# Altium schematic sheet MPU.SchDoc
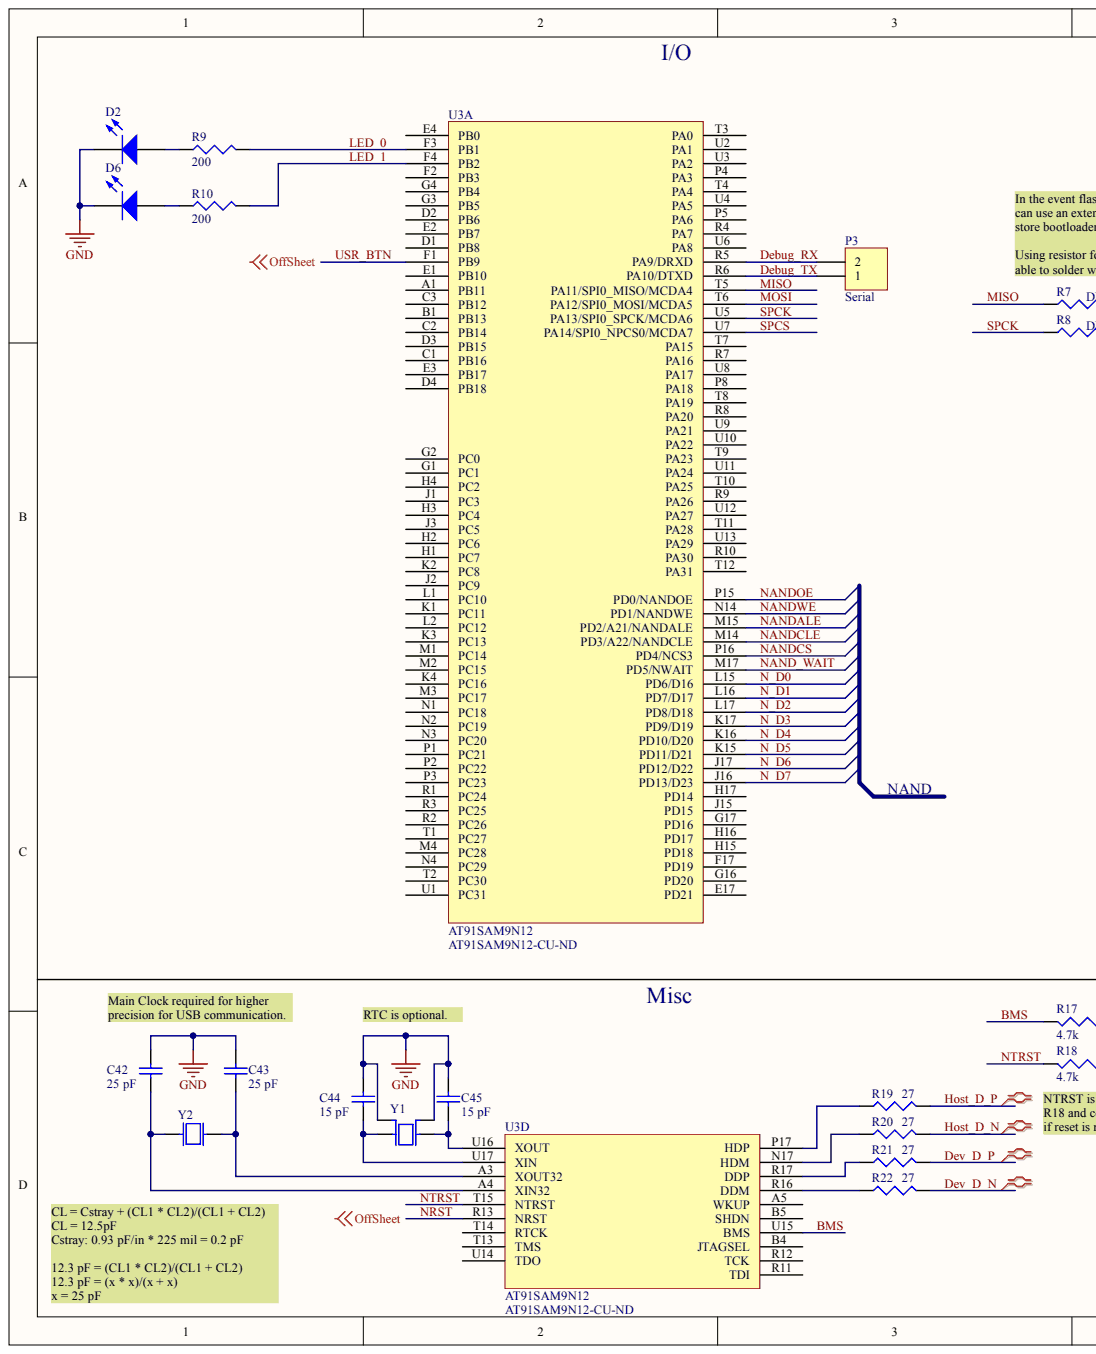
[diagram p0: MPU.SchDoc, left]
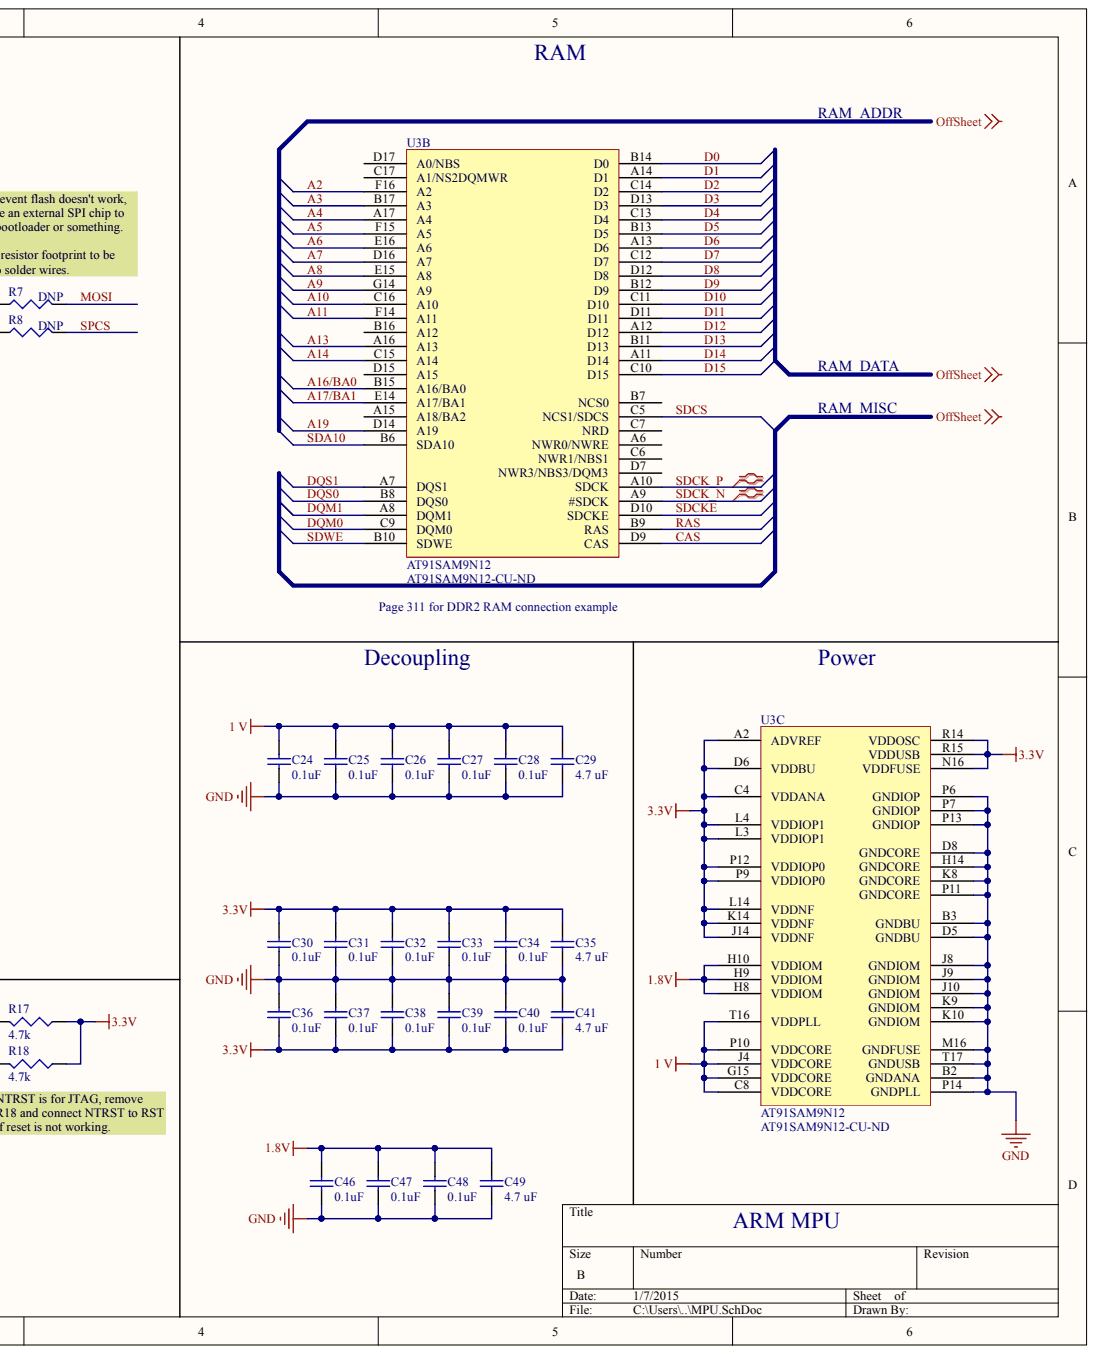
[diagram p1: MPU.SchDoc, right]

## Parts
C24  value 0.1uF  [0402]  (hidden comment: CL05B104KQ5NNNC)
C25  value 0.1uF  [0402]  (hidden comment: CL05B104KQ5NNNC)
C26  value 0.1uF  [0402]  (hidden comment: CL05B104KQ5NNNC)
C27  value 0.1uF  [0402]  (hidden comment: CL05B104KQ5NNNC)
C28  value 0.1uF  [0402]  (hidden comment: CL05B104KQ5NNNC)
C29  value 4.7 uF  [1608[0603]]  (hidden comment: CL10B475KQ8NQNC)
C30  value 0.1uF  [0402]  (hidden comment: CL05B104KQ5NNNC)
C31  value 0.1uF  [0402]  (hidden comment: CL05B104KQ5NNNC)
C32  value 0.1uF  [0402]  (hidden comment: CL05B104KQ5NNNC)
C33  value 0.1uF  [0402]  (hidden comment: CL05B104KQ5NNNC)
C34  value 0.1uF  [0402]  (hidden comment: CL05B104KQ5NNNC)
C35  value 4.7 uF  [1608[0603]]  (hidden comment: CL10B475KQ8NQNC)
C36  value 0.1uF  [0402]  (hidden comment: CL05B104KQ5NNNC)
C37  value 0.1uF  [0402]  (hidden comment: CL05B104KQ5NNNC)
C38  value 0.1uF  [0402]  (hidden comment: CL05B104KQ5NNNC)
C39  value 0.1uF  [0402]  (hidden comment: CL05B104KQ5NNNC)
C40  value 0.1uF  [0402]  (hidden comment: CL05B104KQ5NNNC)
C41  value 4.7 uF  [1608[0603]]  (hidden comment: CL10B475KQ8NQNC)
C42  value 25 pF  [0402]  (hidden comment: CL05C220JB5NNNC)
C43  value 25 pF  [0402]  (hidden comment: CL05C220JB5NNNC)
C44  value 15 pF  [0402]  (hidden comment: CL05C150JB5NNNC)
C45  value 15 pF  [0402]  (hidden comment: CL05C150JB5NNNC)
C46  value 0.1uF  [0402]  (hidden comment: CL05B104KQ5NNNC)
C47  value 0.1uF  [0402]  (hidden comment: CL05B104KQ5NNNC)
C48  value 0.1uF  [0402]  (hidden comment: CL05B104KQ5NNNC)
C49  value 4.7 uF  [1608[0603]]  (hidden comment: CL10B475KQ8NQNC)
D2  LSQ976-Z  [LSQ976-Z]  (value not drawn)
D6  LSQ976-Z  [LSQ976-Z]  (value not drawn)
P3  Serial  [HDR1X2]
R7  DNP  [J1-0603]
R8  DNP  [J1-0603]
R9  value 200  [J1-0603]  (hidden comment: MCR03ERTJ201)
R10  value 200  [J1-0603]  (hidden comment: MCR03ERTJ201)
R17  value 4.7k  [J1-0603]  (hidden comment: RMCF0603JT4K70)
R18  value 4.7k  [J1-0603]  (hidden comment: RMCF0603JT4K70)
R19  value 27  [J1-0603]  (hidden comment: MCR03ERTJ270)
R20  value 27  [J1-0603]  (hidden comment: MCR03ERTJ270)
R21  value 27  [J1-0603]  (hidden comment: MCR03ERTJ270)
R22  MCR03ERTJ270  [J1-0603]  (value not drawn)
U3  AT91SAM9N12  Supplier Part Number 1: AT91SAM9N12-CU-ND  [LFBGA217_V2]  (parts A,B,C,D)
Y1  CX2016DB16000D0FLJCC  [CRYSTAL 16MHZ 8PF SMD]  (value not drawn)
Y2  CFS206  [32.768 Crystal Th-Hole]  (value not drawn)

## Nets
1 V: C24.2 C25.2 C26.2 C27.2 C28.2 C29.2 U3.C8 U3.G15 U3.J4 U3.P10 U3.T16
1.8V: C46.2 C47.2 C48.2 C49.2 U3.H8 U3.H9 U3.H10
3.3V: C30.2 C31.2 C32.1 C33.2 C34.2 C35.2 C36.1 C37.1 C38.1 C39.1 C40.1 C41.1 R17.1 R18.1 U3.A2 U3.C4(VDDANA) U3.D6 U3.J14 U3.K14 U3.L3 U3.L4 U3.L14 U3.N16 U3.P9 U3.P12 U3.R14 U3.R15
GND: C24.1 C25.1 C26.1 C27.1 C28.1 C29.1 C30.1 C31.1 C32.2 C33.1 C34.1 C35.1 C36.2 C37.2 C38.2 C39.2 C40.2 C41.2 C42.1 C43.1 C44.1 C45.1 C46.1 C47.1 C48.1 C49.1 D2.C D6.C U3.B2 U3.B3 U3.D5 U3.D8 U3.H14 U3.J8 U3.J9 U3.J10 U3.K8 U3.K9 U3.K10 U3.M16 U3.P6(GNDIOP) U3.P7 U3.P11 U3.P13 U3.P14 U3.T17 Y1.2 Y1.4
A2: U3.F16(A2)
A3: U3.B17(A3)
A4: U3.A17(A4)
A5: U3.F15(A5)
A6: U3.E16(A6)
A7: U3.D16(A7)
A8: U3.E15(A8)
A9: U3.G14(A9)
A10: U3.C16(A10)
A11: U3.F14(A11)
A13: U3.A16(A13)
A14: U3.C15(A14)
A16/BA0: U3.B15(A16/BA0)
A17/BA1: U3.E14(A17/BA1)
A19: U3.D14(A19)
BMS: R17.2 U3.U15(JTAGSEL)
CAS: U3.D9(CAS)
D0: U3.B14(D0)
D1: U3.A14(D1)
D2: U3.C14(D2)
D3: U3.D13(D3)
D4: U3.C13(D4)
D5: U3.B13(D5)
D6: U3.A13(D6)
D7: U3.C12(D7)
D8: U3.D12(D8)
D9: U3.B12(D9)
D10: U3.C11(D10)
D11: U3.D11
D12: U3.A12(D12)
D13: U3.B11(D13)
D14: U3.A11(D14)
D15: U3.C10(D15)
DEBUG_RX: P3.2 U3.R5(PA9/DRXD)
DEBUG_TX: P3.1 U3.R6(PA10/DTXD)
DEV_D_N: R22.2
DEV_D_P: R21.2
DQM0: U3.C9(DQM0)
DQM1: U3.A8(DQM1)
DQS0: U3.B8(DQS0)
DQS1: U3.A7(DQS1)
HOST_D_N: R20.2
HOST_D_P: R19.2
LED_0: R9.2 U3.F3(PB1)
LED_1: R10.2 U3.F4(PB2)
MISO: R7.1 U3.T5(PA11/SPI0_MISO/MCDA4)
MOSI: R7.2 U3.T6(PA12/SPI0_MOSI/MCDA5)
N_D0: U3.L15
N_D1: U3.L16(PD7/D17)
N_D2: U3.L17(PD8/D18)
N_D3: U3.K17(PD9/D19)
N_D4: U3.K16
N_D5: U3.K15
N_D6: U3.J17
N_D7: U3.J16(PD13/D23)
NAND_WAIT: U3.M17
NANDALE: U3.M15(PD2/A21/NANDALE)
NANDCLE: U3.M14(PD3/A22/NANDCLE)
NANDCS: U3.P16(PD4/NCS3)
NANDOE: U3.P15(PD0/NANDOE)
NANDWE: U3.N14(PD1/NANDWE)
NRST: U3.R13
NTRST: R18.2 U3.T15(NRST)
RAS: U3.B9(RAS)
SDA10: U3.B6(SDA10)
SDCK_N: U3.A9(#SDCK)
SDCK_P: U3.A10(SDCK)
SDCKE: U3.D10(SDCKE)
SDCS: U3.C5(NCS1/SDCS)
SDWE: U3.B10(SDWE)
SPCK: R8.1 U3.U5(PA13/SPI0_SPCK/MCDA6)
SPCS: R8.2 U3.U7(PA14/SPI0_NPCS0/MCDA7)
USR_BTN: U3.F1(PB9)
NetC42_2: C42.2 U3.A4(NTRST) Y2.1
NetC43_2: C43.2 U3.A3(XIN32) Y2.2
NetC44_2: C44.2 U3.U17(XOUT32) Y1.3
NetC45_2: C45.2 U3.U16(XIN) Y1.1
NetD2_A: D2.A R9.1
NetD6_A: D6.A R10.1
NetR19_1: R19.1 U3.P17(HDM)
NetR20_1: R20.1 U3.N17(DDP)
NetR21_1: R21.1 U3.R17(DDM)
NetR22_1: R22.1 U3.R16(WKUP)
N00235:
N00236:
N00237:
N00238:
OFFSHEET:

Not connected: U3 A1(PB11) A5(SHDN) A6(NWR0/NWRE) A15(A18/BA2) B1(PB13) B4(TCK) B5 B7(NCS0) B16(A12) C1(PB16) C2(PB14) C3(PB12) C6(NWR1/NBS1) C7(NRD) C17(A1/NS2DQMWR) D1(PB8) D2(PB6) D3(PB15) D4(PB18) D7(NWR3/NBS3/DQM3) D15(A15) D17(A0/NBS) E1(PB10) E2(PB7) E3(PB17) E4(PB0) E17 F2(PB3) F17 G1(PC1) G2(PC0) G3(PB5) G4(PB4) G16 G17 H1(PC7) H2(PC6) H3(PC4) H4(PC2) H15 H16 H17(PD14) J1(PC3) J2(PC9) J3(PC5) J15 K1(PC11) K2(PC8) K3(PC13) K4 L1(PC10) L2(PC12) M1(PC14) M2 M3(PC17) M4 N1(PC18) N2(PC19) N3 N4 P1 P2 P3(PC23) P4(PA3) P5(PA6) P8(PA18) R1(PC24) R2 R3 R4(PA7) R7(PA16) R8(PA20) R9(PA26) R10(PA30) R11 R12(TDI) T1 T2 T3(PA0) T4(PA4) T7(PA15) T8(PA19) T9(PA23) T10(PA25) T11(PA28) T12(PA31) T13(TDO) T14(TMS) U1 U2(PA1) U3(PA2) U4(PA5) U6(PA8) U8(PA17) U9(PA21) U10(PA22) U11(PA24) U12(PA27) U13(PA29) U14

## Library data of the parts
C24-C28 C30-C34 C36-C40 C46-C48 = CL05B104KQ5NNNC: Cap Ceramic 0.1uF 6.3V X7R 10% SMD 0402; Component Kind: Standard; LatestRevisionDate: 29-May-2009; LatestRevisionNote: IPC-7351 Footprint Added.; PackageDescription: Chip Capacitor; PackageReference: C1206; Published: 8-Jun-2000; Signal Integrity: Cap Semi; Simulation: CAP; Supplier 1: Arrow; Supplier 2: Digi-Key; Supplier Part Number 1: c0Ft5huTF1PnwzXLA59QPYxRs3E3aghew1LSAii7cAMZVywxIBNyoAQstvnyfZZ0; Supplier Part Number 2: 1276-1511-1-ND; Library Name: Linux_Board_SCHEM_LIB.SchLib; Publisher: Altium Limited
C29 C35 C41 C49 = CL10B475KQ8NQNC: CAP CER 4.7UF 6.3V 10% X7R 0603; Component Kind: Standard; LatestRevisionDate: 29-May-2009; LatestRevisionNote: IPC-7351 Footprint Added.; PackageDescription: Chip Capacitor; PackageReference: C1206; Published: 8-Jun-2000; Signal Integrity: Cap Semi; Simulation: CAP; Supplier 1: Digi-Key; Supplier Part Number 1: 1276-2087-1-ND; Library Name: Linux_Board_SCHEM_LIB.SchLib; Publisher: Altium Limited
C42 C43 = CL05C220JB5NNNC: CAP CER 22PF 50V 5% NP0 0402; Component Kind: Standard; LatestRevisionDate: 29-May-2009; LatestRevisionNote: IPC-7351 Footprint Added.; PackageDescription: Chip Capacitor; PackageReference: C1206; Published: 8-Jun-2000; Signal Integrity: Cap Semi; Simulation: CAP; Supplier 1: Digi-Key; Supplier Part Number 1: 1276-1116-1-ND; Library Name: Linux_Board_SCHEM_LIB.SchLib; Publisher: Altium Limited
C44 C45 = CL05C150JB5NNNC: CAP CER 15PF 50V 5% NP0 0402; Component Kind: Standard; LatestRevisionDate: 29-May-2009; LatestRevisionNote: IPC-7351 Footprint Added.; PackageDescription: Chip Capacitor; PackageReference: C1206; Published: 8-Jun-2000; Signal Integrity: Cap Semi; Simulation: CAP; Supplier 1: Digi-Key; Supplier Part Number 1: 1276-1116-1-ND; Library Name: Linux_Board_SCHEM_LIB.SchLib; Publisher: Altium Limited
D2 D6 = LSQ976-Z: OSRAM - LED, SMD, RED, 180MCD, 633NM; Component Kind: Standard; Signal Integrity: Guessed Model; Supplier 1: Mouser; Supplier 2: Newark; Supplier Part Number 1: N/A; Supplier Part Number 2: 05M0541; Library Name: Linux_Board_SCHEM_LIB.SchLib
P3 = Header 2: Header, 2-Pin; Component Kind: Standard; LatestRevisionDate: 17-Jul-2002; LatestRevisionNote: Re-released for DXP Platform.; Library Name: Miscellaneous Connectors.IntLib; Signal Integrity: Connector; Publisher: Altium Limited
R7 R8 R19-R21 = MCR03ERTJ270: RES SMD 27 OHM 5% 1/10W 0603; Component Kind: Standard; LatestRevisionDate: 29-May-2009; LatestRevisionNote: IPC-7351 Footprint Added.; PackageDescription: Chip Resistor; PackageReference: J1-0603; Published: 8-Jun-2000; Signal Integrity: Res; Simulation: RESISTOR; Supplier 1: Digi-Key; Supplier Part Number 1: RHM27CGCT-ND; Library Name: Linux_Board_SCHEM_LIB.SchLib; Publisher: Altium Limited
R9 R10 = MCR03ERTJ201: RES 200 OHM 1/10W 5% 0603 SMD; Component Kind: Standard; LatestRevisionDate: 29-May-2009; LatestRevisionNote: IPC-7351 Footprint Added.; PackageDescription: Chip Resistor; PackageReference: J1-0603; Published: 8-Jun-2000; Signal Integrity: Res; Simulation: RESISTOR; Supplier 1: Digi-Key; Supplier Part Number 1: RHM200CGCT-ND; Library Name: Linux_Board_SCHEM_LIB.SchLib; Publisher: Altium Limited
R17 R18 = RMCF0603JT4K70: RES 4.7K OHM 1/10W 5% 0603; Component Kind: Standard; LatestRevisionDate: 29-May-2009; LatestRevisionNote: IPC-7351 Footprint Added.; PackageDescription: Chip Resistor; PackageReference: J1-0603; Published: 8-Jun-2000; Signal Integrity: Res; Simulation: RESISTOR; Supplier 1: Digi-Key; Supplier 2: Arrow; Supplier Part Number 1: RMCF0603JT4K70CT-ND; Supplier Part Number 2: QpvnIvxQrb47WQ_XjFL3E5TkNE8-LA98qHlgf5QoEq8; Library Name: Linux_Board_SCHEM_LIB.SchLib; Publisher: Altium Limited
R22 = MCR03ERTJ270: RES SMD 27 OHM 5% 1/10W 0603; Value: 27; Component Kind: Standard; LatestRevisionDate: 29-May-2009; LatestRevisionNote: IPC-7351 Footprint Added.; PackageDescription: Chip Resistor; PackageReference: J1-0603; Published: 8-Jun-2000; Signal Integrity: Res; Simulation: RESISTOR; Supplier 1: Digi-Key; Supplier Part Number 1: RHM27CGCT-ND; Library Name: Linux_Board_SCHEM_LIB.SchLib; Publisher: Altium Limited
U3 = AT91SAM9N12_217_BGA: 400 MHZ Microprocessor Atmel; Component Kind: Standard; Supplier 1: Digi-Key; Supplier 2: Digi-Key; Supplier 3: Digi-Key; Supplier 4: Digi-Key; Supplier 5: Mouser; Library Name: Linux_Board_SCHEM_LIB.SchLib
Y1 = CX2016DB16000D0FLJCC: CRYSTAL 16MHZ 8PF SMD; Component Kind: Standard; Supplier 1: Digi-Key; Supplier Part Number 1: 1253-1348-1-ND; Library Name: Linux_Board_SCHEM_LIB.SchLib
Y2 = CFS206: CRYSTAL 32.768KHZ 12.5PF THRU; Component Kind: Standard; Supplier 1: Digi-Key; Supplier Part Number 1: 300-1002-ND; Library Name: Linux_Board_SCHEM_LIB.SchLib
